# Altium schematic sheet 2. Connectors.SchDoc
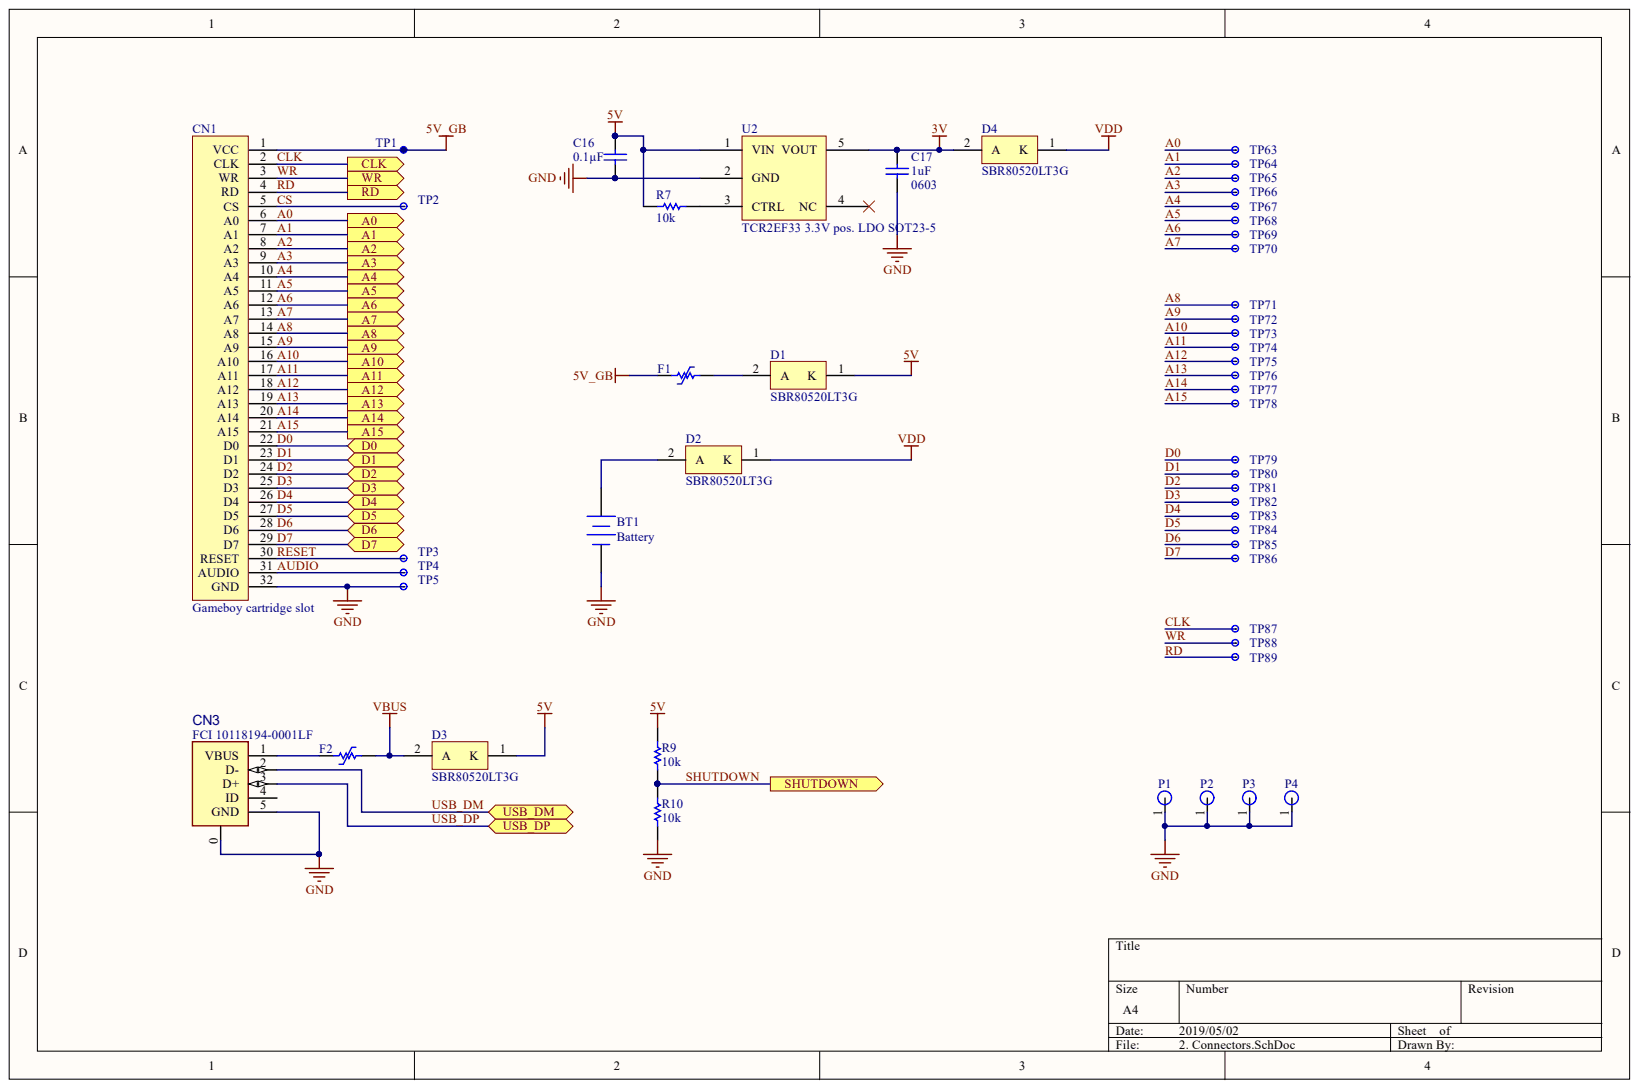
[diagram p0: 2. Connectors.SchDoc]

## Parts
BT1  Battery  [PCB-65mdyjamygte6kiajklb-1]
C16  Capacitance: 0.1µF  [PCB-mhhqftc5ilnrg4qi8ds6-1]  (hidden comment: Cap)
C17  Capacitance: 1uF  Case/Package: 0603  [0603C_B]  (hidden comment: Yageo CC0603KRX7R7BB104)
CN1  Gameboy cartridge slot  [PCB-uwgfzvbds2v1ko11221f-1]
CN3  FCI 10118194-0001LF  [PCB-edlm2od7brxgpj78b9fm-1]
D1  SBR80520LT3G  [ONSC-SOD-123-2-425-04_V]
D2  SBR80520LT3G  [ONSC-SOD-123-2-425-04_V]
D3  SBR80520LT3G  [ONSC-SOD-123-2-425-04_V]
D4  SBR80520LT3G  [ONSC-SOD-123-2-425-04_V]
F1  Bel Fuse 0ZCJ0005FF2E  [PCB-g6xbbgrf1j6f6hdcs2hx-1]  (value not drawn)
F2  Bel Fuse 0ZCJ0005FF2E  [PCB-g6xbbgrf1j6f6hdcs2hx-1]  (value not drawn)
P1  Würth Elektronik 9774040243R  [PCB-6bnlwl84ripo9jvz9fp6-1]  (value not drawn)
P2  Würth Elektronik 9774040243R  [PCB-6bnlwl84ripo9jvz9fp6-1]  (value not drawn)
P3  Würth Elektronik 9774040243R  [PCB-6bnlwl84ripo9jvz9fp6-1]  (value not drawn)
P4  Würth Elektronik 9774040243R  [PCB-6bnlwl84ripo9jvz9fp6-1]  (value not drawn)
R7  Resistance: 10k  [R0603_A]  (hidden comment: YAGEO RC0603JR-0710KL)
R9  Resistance: 10k  [R0603_A]  (hidden comment: YAGEO RC0603JR-0710KL)
R10  Resistance: 10k  [R0603_A]  (hidden comment: YAGEO RC0603JR-0710KL)
TP1  TestPad  [PCB-qqaworq3uifq0h8koz5g-1]  (value not drawn)
TP2  TestPad  [PCB-qqaworq3uifq0h8koz5g-1]  (value not drawn)
TP3  TestPad  [PCB-qqaworq3uifq0h8koz5g-1]  (value not drawn)
TP4  TestPad  [PCB-qqaworq3uifq0h8koz5g-1]  (value not drawn)
TP5  TestPad  [PCB-qqaworq3uifq0h8koz5g-1]  (value not drawn)
TP63  TestPad  [PCB-qqaworq3uifq0h8koz5g-1]  (value not drawn)
TP64  TestPad  [PCB-qqaworq3uifq0h8koz5g-1]  (value not drawn)
TP65  TestPad  [PCB-qqaworq3uifq0h8koz5g-1]  (value not drawn)
TP66  TestPad  [PCB-qqaworq3uifq0h8koz5g-1]  (value not drawn)
TP67  TestPad  [PCB-qqaworq3uifq0h8koz5g-1]  (value not drawn)
TP68  TestPad  [PCB-qqaworq3uifq0h8koz5g-1]  (value not drawn)
TP69  TestPad  [PCB-qqaworq3uifq0h8koz5g-1]  (value not drawn)
TP70  TestPad  [PCB-qqaworq3uifq0h8koz5g-1]  (value not drawn)
TP71  TestPad  [PCB-qqaworq3uifq0h8koz5g-1]  (value not drawn)
TP72  TestPad  [PCB-qqaworq3uifq0h8koz5g-1]  (value not drawn)
TP73  TestPad  [PCB-qqaworq3uifq0h8koz5g-1]  (value not drawn)
TP74  TestPad  [PCB-qqaworq3uifq0h8koz5g-1]  (value not drawn)
TP75  TestPad  [PCB-qqaworq3uifq0h8koz5g-1]  (value not drawn)
TP76  TestPad  [PCB-qqaworq3uifq0h8koz5g-1]  (value not drawn)
TP77  TestPad  [PCB-qqaworq3uifq0h8koz5g-1]  (value not drawn)
TP78  TestPad  [PCB-qqaworq3uifq0h8koz5g-1]  (value not drawn)
TP79  TestPad  [PCB-qqaworq3uifq0h8koz5g-1]  (value not drawn)
TP80  TestPad  [PCB-qqaworq3uifq0h8koz5g-1]  (value not drawn)
TP81  TestPad  [PCB-qqaworq3uifq0h8koz5g-1]  (value not drawn)
TP82  TestPad  [PCB-qqaworq3uifq0h8koz5g-1]  (value not drawn)
TP83  TestPad  [PCB-qqaworq3uifq0h8koz5g-1]  (value not drawn)
TP84  TestPad  [PCB-qqaworq3uifq0h8koz5g-1]  (value not drawn)
TP85  TestPad  [PCB-qqaworq3uifq0h8koz5g-1]  (value not drawn)
TP86  TestPad  [PCB-qqaworq3uifq0h8koz5g-1]  (value not drawn)
TP87  TestPad  [PCB-qqaworq3uifq0h8koz5g-1]  (value not drawn)
TP88  TestPad  [PCB-qqaworq3uifq0h8koz5g-1]  (value not drawn)
TP89  TestPad  [PCB-qqaworq3uifq0h8koz5g-1]  (value not drawn)
U2  TCR2EF33 3.3V pos. LDO SOT23-5  [PCB-vnad9jnmv6teh67tclgx-1]

## Ports
A0, A1, A2, A3, A4, A5, A6, A7, A8, A9, A10, A11, A12, A13, A14, A15, CLK, D0, D1, D2, D3, D4, D5, D6, D7, RD, SHUTDOWN, USB_DM, USB_DP, WR

## Nets
3V: C17.1 D4.2 U2.5(VOUT)
5V: C16.2 D1.1 D3.1 R7.2 R9.1 U2.1(VIN)
5V_GB: CN1.1(VCC) F1.1 TP1.1
GND: BT1.2 C16.1 C17.2 CN1.32(GND) CN3.0 CN3.5(GND) P1.1 P2.1 P3.1 P4.1 R10.2 TP5.1 U2.2(GND)
VBUS: D3.2 F2.2
VDD: D2.1 D4.1
A0: CN1.6(A0) TP63.1 ; port A0
A1: CN1.7(A1) TP64.1 ; port A1
A2: CN1.8(A2) TP65.1 ; port A2
A3: CN1.9(A3) TP66.1 ; port A3
A4: CN1.10(A4) TP67.1 ; port A4
A5: CN1.11(A5) TP68.1 ; port A5
A6: CN1.12(A6) TP69.1 ; port A6
A7: CN1.13(A7) TP70.1 ; port A7
A8: CN1.14(A8) TP71.1 ; port A8
A9: CN1.15(A9) TP72.1 ; port A9
A10: CN1.16(A10) TP73.1 ; port A10
A11: CN1.17(A11) TP74.1 ; port A11
A12: CN1.18(A12) TP75.1 ; port A12
A13: CN1.19(A13) TP76.1 ; port A13
A14: CN1.20(A14) TP77.1 ; port A14
A15: CN1.21(A15) TP78.1 ; port A15
AUDIO: CN1.31(AUDIO) TP4.1
CLK: CN1.2(CLK) TP87.1 ; port CLK
CS: CN1.5(CS) TP2.1
D0: CN1.22(D0) TP79.1 ; port D0
D1: CN1.23(D1) TP80.1 ; port D1
D2: CN1.24(D2) TP81.1 ; port D2
D3: CN1.25(D3) TP82.1 ; port D3
D4: CN1.26(D4) TP83.1 ; port D4
D5: CN1.27(D5) TP84.1 ; port D5
D6: CN1.28(D6) TP85.1 ; port D6
D7: CN1.29(D7) TP86.1 ; port D7
RD: CN1.4(RD) TP89.1 ; port RD
RESET: CN1.30(RESET) TP3.1
SHUTDOWN: R9.2 R10.1 ; port SHUTDOWN
USB_DM: CN3.2(D-) ; port USB_DM
USB_DP: CN3.3(D+) ; port USB_DP
WR: CN1.3(WR) TP88.1 ; port WR
NetBT1_1: BT1.1 D2.2
NetCN3_1: CN3.1(VBUS) F2.1
NetD1_2: D1.2 F1.2
NetR7_1: R7.1 U2.3(CTRL)

Not connected: CN3 4(ID); U2 4(NC)

## Library data of the parts
BT1 = CMP-fda93507aa51226e-3: Holder Battery; Coin Battery; 1 Cell; Tab; 0.787 in. Dia.; Manufacturer Part Number: 3034; Lead-Free Status: Lead Free; Color: Black; Component Kind: Standard; Contact Material: Phosphor Bronze; Contact Plating: Nickel; Library Name: CommunityVault
C16 = CMP-1af8d38ac64bc53f-4: CAP CER 0.1UF 50V X7R 0603; Component Kind: Standard; HelpURL: https://media.digikey.com/pdf/Data%20Sheets/Samsung%20PDFs/CL_Series_MLCC_ds.pdf; Library Name: CommunityVault; OctopartID: 1af8d38ac64bc53f; Size / Dimension: 0.063" L x 0.031" W (1.60mm x 0.80mm); Voltage - Rated: 50V
C17 = CMP-123646-11: 0603 0.1 uF 16 V ±10 % Tolerance X7R SMT Multilayer Ceramic Capacitor; Insulation Resistance: 100 GΩ; Lead-Free Status: Lead Free; Voltage Rating (DC): 16.0 V; Component Kind: Standard; Halogen Free Status: Halogen Free; Library Name: CommunityVault; Manufacturer Part Number: CC0603KRX7R7BB104; Mounting Style: Surface Mount; Number of Pins: 2; OctopartID: 6529ac21b1e2baee; Octopart Url: http://octopart.com/cc0603krx7r7bb104-yageo-192941; Packaging: Cut Tape (CT), Tape & Reel (TR); REACH SVHC Compliance: No SVHC; RoHS: Compliant; Seller Landing  Url: https://octopart-clicks.com/click/track?country=US&ak=8157f166&sig=06d218e&sid=1106&ppid=192941&vpid=198824028&ct=offers; Size-Height: 810 µm; Size-Length: 1.60 mm; Size-Width: 810 µm
CN1 = Gameboy cartridge slot-1: CustomComponentID: parj658x5fvcvx9g97sx; Component Kind: Standard; Description: Gameboy cartridge slot; Library Name: FavoritesLibrary
CN3 = CMP-2509ed477c75face-11: Single Port 5 Contact Horizontal Micro B USB 2.0 Connector; Component Kind: Standard; Library Name: CommunityVault
D1 = CMP-822511-2: Schottky Power Rectifier, 2-Pin SOD-123, Pb-Free, Tape and Reel; Lead-Free Status: Lead Free; Case/Package: SOD-123; Component Kind: Standard; Halogen Free Status: Halogen Free; Library Name: CommunityVault; Lifecycle Status: Active; Manufacturer Part Number: SBR80520LT3G; OctopartID: 43588b03da839c9f; Octopart Url: https://octopart.com/sbr80520lt3g-on+semiconductor-22133949; RoHS: Compliant
D2-D4 = SBR80520LT3G-2: Schottky Power Rectifier, 2-Pin SOD-123, Pb-Free, Tape and Reel; Lead-Free Status: Lead Free; Case/Package: SOD-123; Component Kind: Standard; Halogen Free Status: Halogen Free; Library Name: FavoritesLibrary; Lifecycle Status: Active; OctopartID: 43588b03da839c9f; Octopart Url: https://octopart.com/sbr80520lt3g-on+semiconductor-22133949; RoHS: Compliant
F1 F2 = Bel Fuse 0ZCJ0005FF2E-1: PTC Resettable Fuse 0.05A(hold) 0.15A(trip) 60VDC 10A 0.4W 1.5s 3.6Ohm SMD Solder Pad 3.5 X 1.8 X 0.85mm T/R; Lead-Free Status: Lead Free; Component Kind: Standard; Library Name: FavoritesLibrary; Manufacturer Part Number: 0ZCJ0005FF2E; OctopartID: 07f4cf3e8fc9d833; Octopart URL: http://octopart.com/0zcj0005ff2e-bel+fuse-29404507; RoHS: Compliant
P1-P4 = CMP-48c4825e7a369703-1: Spacer Material: Steel, Tin-Plated Mounting: SMT Series: WA-SMST Thread D: M2; Component Kind: Standard; Library Name: CommunityVault; Manufacturer Part Number: 9774040243R; Material: Steel; OctopartID: 48c4825e7a369703; RoHS: Compliant
R7 R9 R10 = CMP-c8530329081a1794-1: Res Thick Film 0603 47 Ohm 5% 0.1W(1/10W) ?100ppm/C Molded SMD Paper T/R; Lead-Free Status: Lead Free; Voltage Rating (DC): 50.0 V; Case/Package: 0603; Component Kind: Standard; Library Name: CommunityVault; Manufacturer Part Number: RC0603JR-0710KL; Mounting Style: Surface Mount; Number of Pins: 2; OctopartID: c8530329081a1794; Power Rating: 0.1 W; REACH SVHC Compliance: No SVHC; Resistance Tolerance: ±5%; RoHS: Compliant; Size-Height: 0.00045 m; Size-Length: 0.0016 m; Size-Width: 0.0008 m
TP1-TP5 TP63-TP89 = CMP-822f97gjbv438nmxigx5-1: Component Kind: Standard; CustomComponentID: 822f97gjbv438nmxigx5; Description: TestPad; Library Name: CommunityVault
U2 = CMP-a34c689ca3827c8b-1: LDO Regulator Pos 3.3V 0.2A 5-Pin SOT-25; Component Kind: Standard; Library Name: CommunityVault; Mounting Style: Surface Mount; OctopartID: a34c689ca3827c8b; Octopart URL: http://octopart.com/tcr2ef33%2Clm%28ct-toshiba-47850920; Packaging: Tape & Reel (TR)
